# Altium schematic sheet PowerFiltering.SchDoc(PowerFiltering)
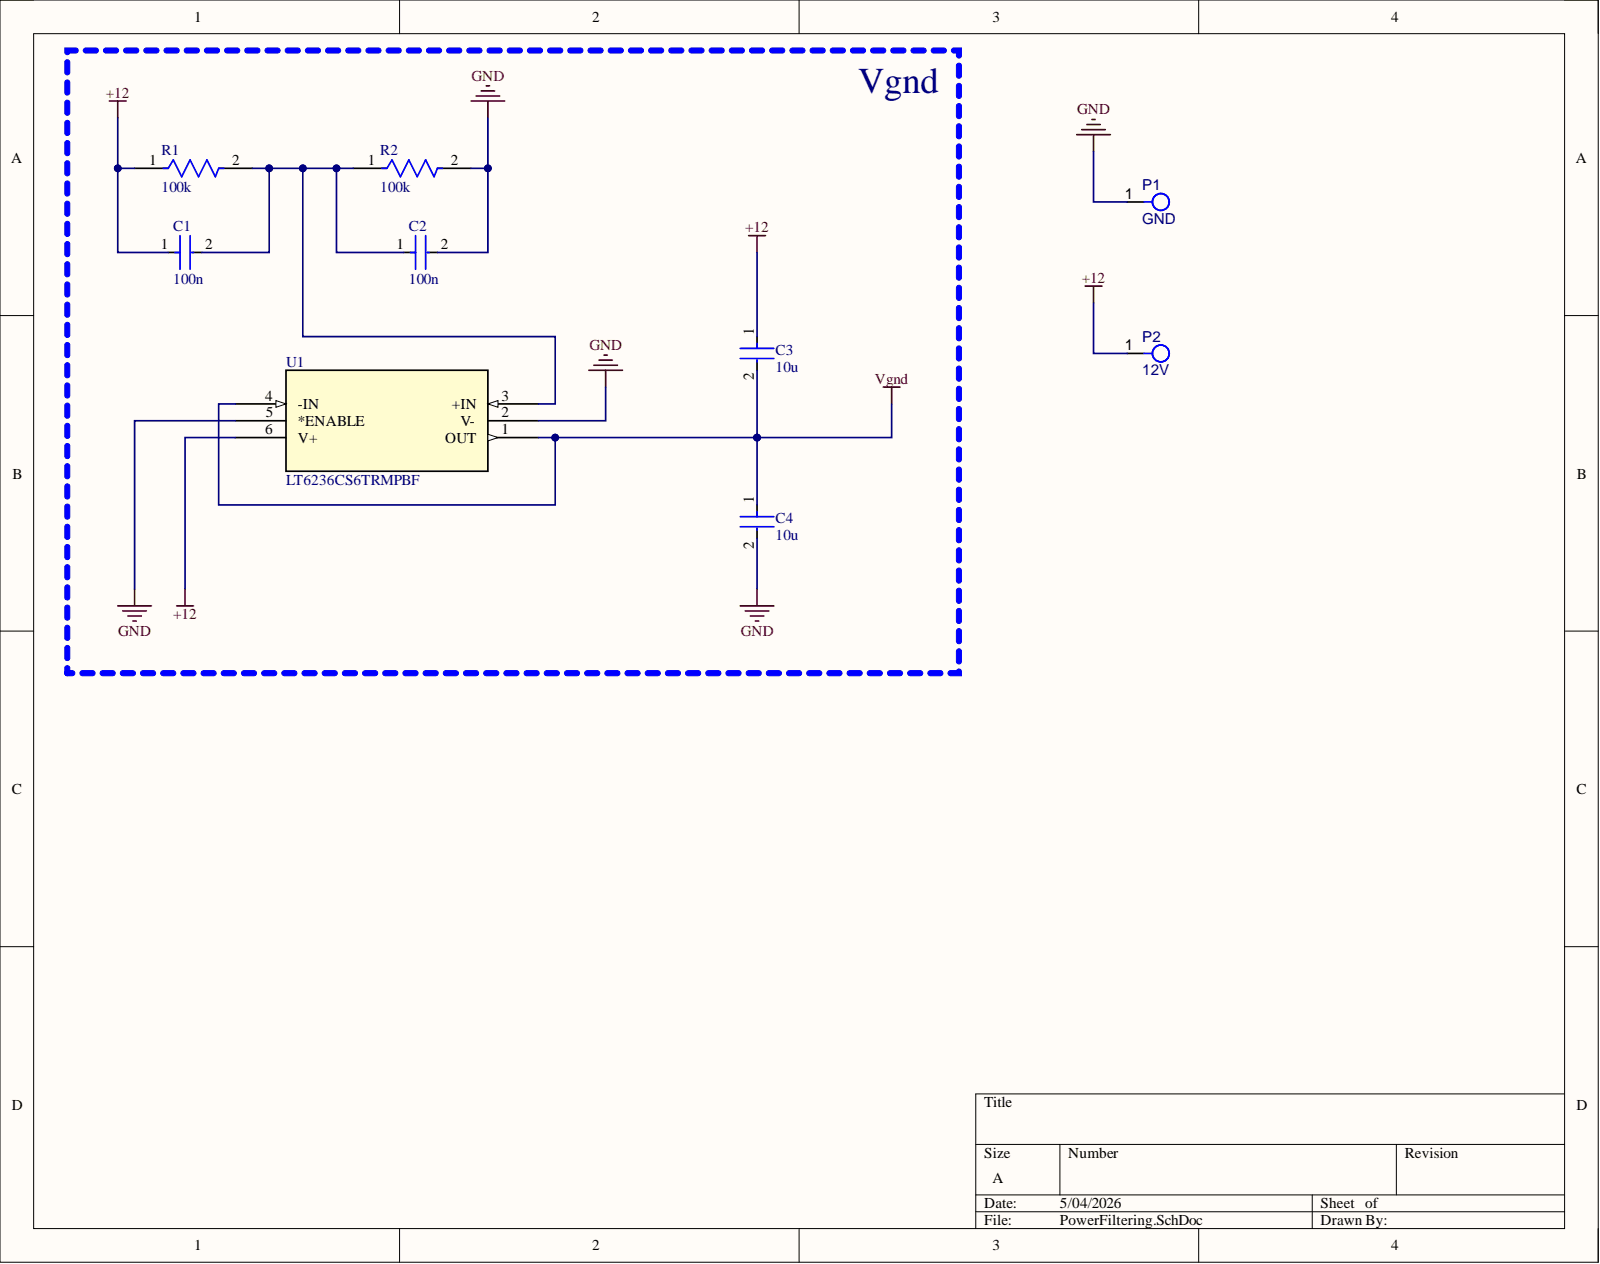
[diagram p0: PowerFiltering.SchDoc]

## Parts
C1  100n
C2  100n
C3  10u
C4  10u
P1  GND  (no BOM)
P2  12V  (no BOM)
R1  100k
R2  100k
U1  LT6236CS6TRMPBF

## Nets
+12: C1.1 C3.1 P2.1 R1.1 U1.6(V+)
GND: C2.2 C4.2 P1.1 R2.2 U1.2(V-) U1.5(*ENABLE)
Vgnd: C3.2 C4.1 U1.1(OUT) U1.4(-IN)
NetC1_2: C1.2 C2.1 R1.2 R2.1 U1.3(+IN)

## Library data of the parts
C1 C2 = CMP-06035-028588-1: Chip Multilayer Ceramic Capacitors for General Purpose, 1206, 0.10uF, C0G, 30ppm/°C, 5%, 50V; Component Kind: Standard; Library Name: Altium Content Vault
C3 C4 = CMP-2000-07555-2: Chip Multilayer Ceramic Capacitors for General Purpose, 0805, 10uF, X7R, 15%, 10%, 10V; Capacitance: 10uF; Case Code (Imperial): 0805; Case Code (Metric): 2012; Case/Package: 0805; Component Kind: Standard; Depth: 1.25mm; Dielectric: X7R; Height: 1.25mm; Length: 2mm; Library Name: Altium Content Vault; Max Operating Temperature: 125°C; Min Operating Temperature: -55°C; Mount: Surface Mount; Package Quantity: 3000; Packaging: Tape and Reel; REACH SVHC: No SVHC; Termination: SMD/SMT; Thickness: 0.055inch; Tolerance: 10%; Voltage Rating: 10V; Voltage Rating (DC): 10V; Weight: 0.000335oz; Width: 1.25mm
P1 P2 = CMP-019-00043-3: SMD WIRE PAD 7 x 4 mm; Pins: 1; Library Name: James Brasfield
R1 R2 = CMP-2000-01971-2: Automotive Metal Thin Film Chip Resistor, 0603, 100kΩ, 0.1%, 25ppm/°C, 0.1W, 100V; Max Power Dissipation: 100mW; Resistance: 100kR; Voltage Rating (DC): 75V; Case Code (Imperial): 0603; Case Code (Metric): 1608; Case/Package: 0603; Component Kind: Standard; Features: Automotive AEC-Q200; Height: 0.02inch; Lead Free: Lead Free; Length: 0.063inch; Library Name: Altium Content Vault; Max Operating Temperature: 155°C; Min Operating Temperature: -55°C; Number of Terminations: 2; Package Quantity: 1; Packaging: Tape and Reel; Power Rating: 100mW; Resistor Type: Thin Film; RoHS Compliant: Yes; Series: RG; Technology: THIN FILM; Temperature Coefficient: 25ppm/°C; Termination: SMD/SMT; Tolerance: 0.1%; Voltage Rating: 100V; Weight: 7.1E-05oz; Width: 0.031inch; Working Voltage: 100V
U1 = CMP-014-00002-1: Rail-to-Rail Output 215MHz, 1.1nV/âˆšHz Op Amp/ SAR ADC Driver; Manufacturer_Name: Analog Devices Inc; Manufacturer_Part_Number: LT6236CS6#TRMPBF; Component Kind: Standard; Datasheet: https://www.analog.com/media/en/technical-documentation/data-sheets/623637fb.pdf; Design Item ID: LT6236CS6TRMPBF; RefDes: RefDes; Source: LT6236CS6TRMPBF.SchLib; Type: Type; Copyright: Copyright (C) 2026 Ultra Librarian. All rights reserved.; Library Name: James Brasfield
